# Altium schematic sheet Controller_Board_Interface.SchDoc
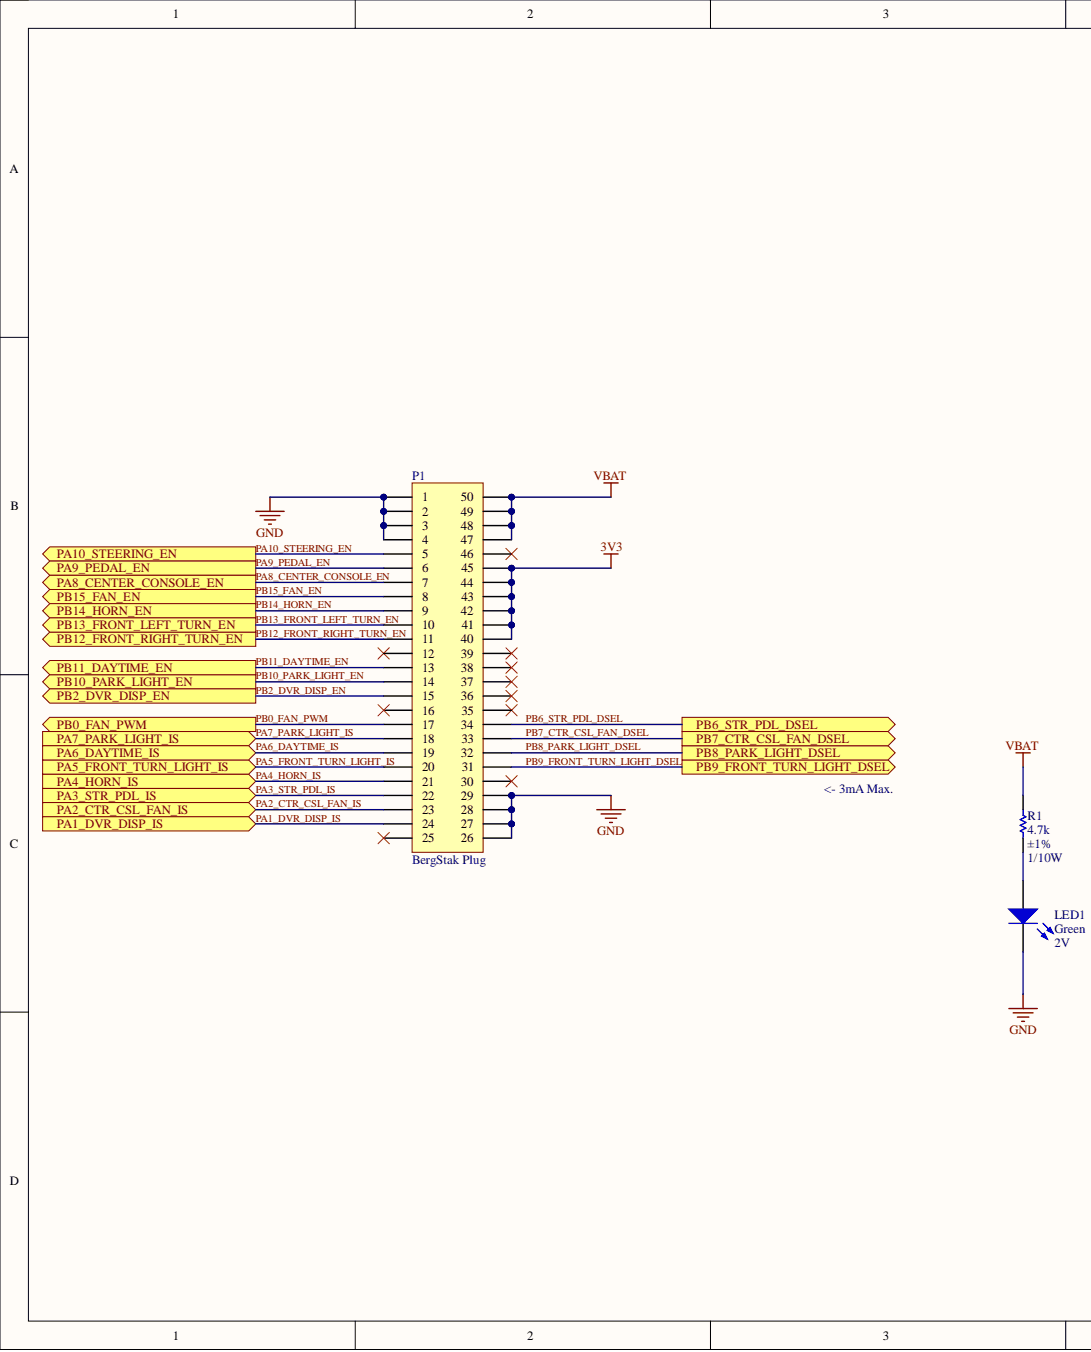
[diagram p0: Controller_Board_Interface.SchDoc, left]
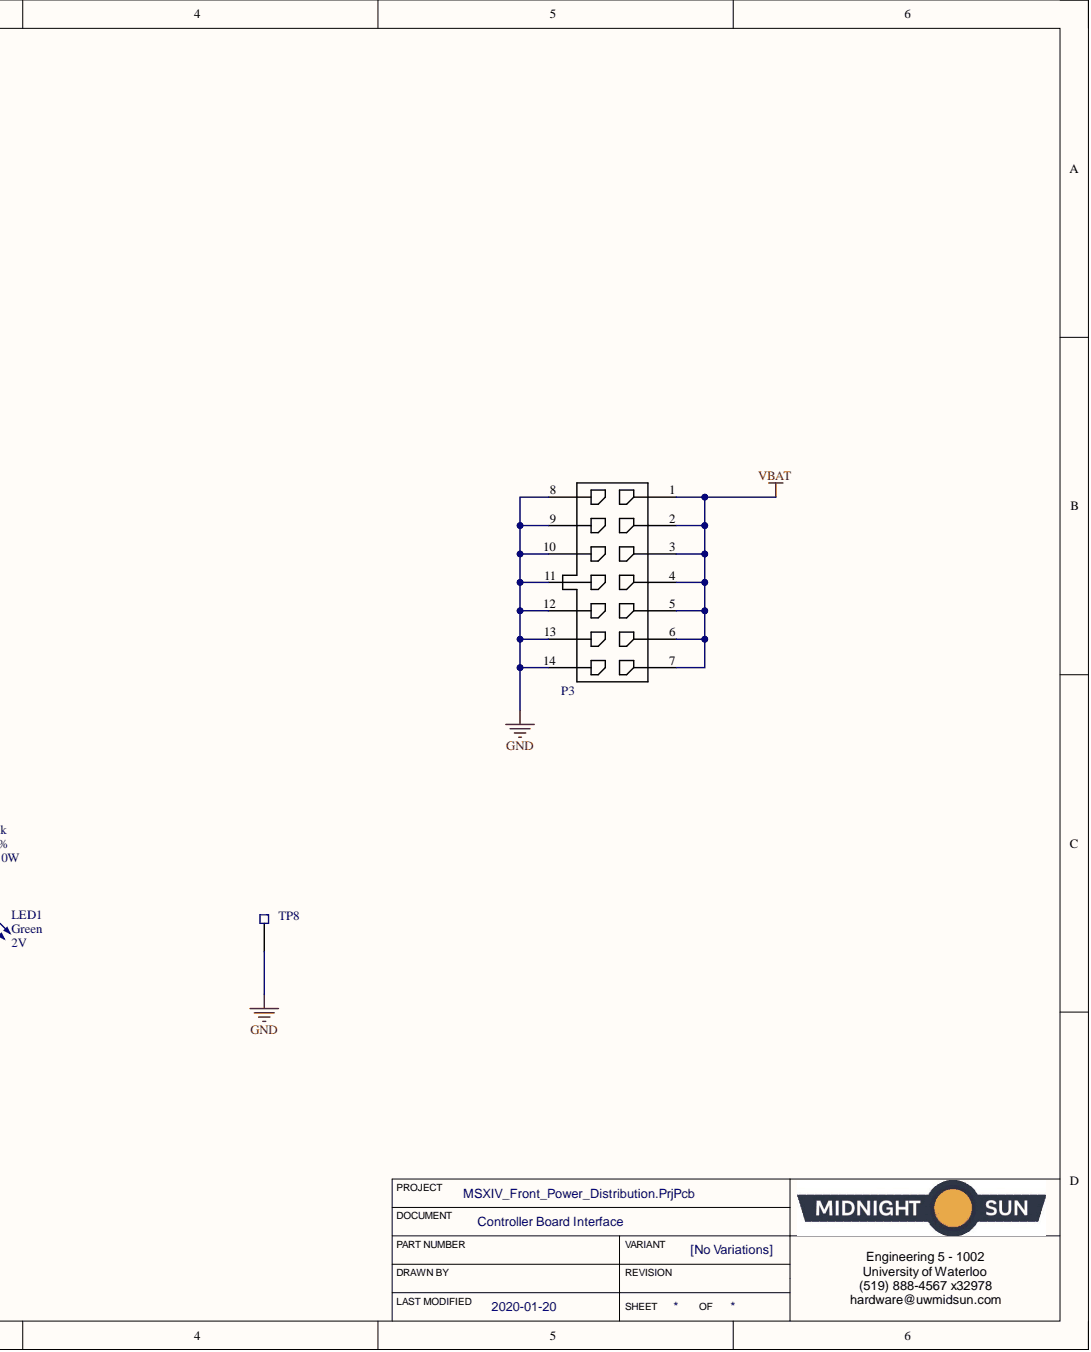
[diagram p1: Controller_Board_Interface.SchDoc, right]

## Parts
LED1  Color: Green  Voltage - Forward (Vf) (Typ): 2V  [LED_0603]  (hidden comment: LED GREEN CLEAR 2V 0603)
P1  Series: BergStak Plug  [CONN, Bergstak 50 Pos Plug]  (hidden comment: CONN 50POS Bergstak Plug 0.02")
P3  CONN 14POS MICRO-FIT 3mm  [CONN, 14POS MICRO-FIT 3mm]  (value not drawn)
R1  Power (Watts): 1/10W  Resistance (Ohms): 4.7k  Tolerance: ±1%  [RES, 0603]  (hidden comment: RES 4.7K OHM 1% 1/10W 0603)
TP8  Test Point 0603 SMD  [TEST POINT 0603 SMD]  (value not drawn)

## Ports
PA1_DVR_DISP_IS, PA2_CTR_CSL_FAN_IS, PA3_STR_PDL_IS, PA4_HORN_IS, PA5_FRONT_TURN_LIGHT_IS, PA6_DAYTIME_IS, PA7_PARK_LIGHT_IS, PA8_CENTER_CONSOLE_EN, PA9_PEDAL_EN, PA10_STEERING_EN, PB0_FAN_PWM, PB2_DVR_DISP_EN, PB6_STR_PDL_DSEL, PB7_CTR_CSL_FAN_DSEL, PB8_PARK_LIGHT_DSEL, PB9_FRONT_TURN_LIGHT_DSEL, PB10_PARK_LIGHT_EN, PB11_DAYTIME_EN, PB12_FRONT_RIGHT_TURN_EN, PB13_FRONT_LEFT_TURN_EN, PB14_HORN_EN, PB15_FAN_EN

## Nets
3V3: P1.40 P1.41 P1.42 P1.43 P1.44 P1.45
GND: LED1.2 P1.1 P1.2 P1.3 P1.4 P1.26 P1.27 P1.28 P1.29 P3.8 P3.9 P3.10 P3.11 P3.12 P3.13 P3.14 TP8.1
VBAT: P1.47 P1.48 P1.49 P1.50 P3.1 P3.2 P3.3 P3.4 P3.5 P3.6 P3.7 R1.1
PA1_DVR_DISP_IS: P1.24 ; port PA1_DVR_DISP_IS
PA2_CTR_CSL_FAN_IS: P1.23 ; port PA2_CTR_CSL_FAN_IS
PA3_STR_PDL_IS: P1.22 ; port PA3_STR_PDL_IS
PA4_HORN_IS: P1.21 ; port PA4_HORN_IS
PA5_FRONT_TURN_LIGHT_IS: P1.20 ; port PA5_FRONT_TURN_LIGHT_IS
PA6_DAYTIME_IS: P1.19 ; port PA6_DAYTIME_IS
PA7_PARK_LIGHT_IS: P1.18 ; port PA7_PARK_LIGHT_IS
PA8_CENTER_CONSOLE_EN: P1.7 ; port PA8_CENTER_CONSOLE_EN
PA9_PEDAL_EN: P1.6 ; port PA9_PEDAL_EN
PA10_STEERING_EN: P1.5 ; port PA10_STEERING_EN
PB0_FAN_PWM: P1.17 ; port PB0_FAN_PWM
PB2_DVR_DISP_EN: P1.15 ; port PB2_DVR_DISP_EN
PB6_STR_PDL_DSEL: P1.34 ; port PB6_STR_PDL_DSEL
PB7_CTR_CSL_FAN_DSEL: P1.33 ; port PB7_CTR_CSL_FAN_DSEL
PB8_PARK_LIGHT_DSEL: P1.32 ; port PB8_PARK_LIGHT_DSEL
PB9_FRONT_TURN_LIGHT_DSEL: P1.31 ; port PB9_FRONT_TURN_LIGHT_DSEL
PB10_PARK_LIGHT_EN: P1.14 ; port PB10_PARK_LIGHT_EN
PB11_DAYTIME_EN: P1.13 ; port PB11_DAYTIME_EN
PB12_FRONT_RIGHT_TURN_EN: P1.11 ; port PB12_FRONT_RIGHT_TURN_EN
PB13_FRONT_LEFT_TURN_EN: P1.10 ; port PB13_FRONT_LEFT_TURN_EN
PB14_HORN_EN: P1.9 ; port PB14_HORN_EN
PB15_FAN_EN: P1.8 ; port PB15_FAN_EN
NetLED1_1: LED1.1 R1.2

Not connected: P1 12 16 25 30 35 36 37 38 39 46

## Library data of the parts
LED1 = LED GREEN CLEAR 2V 0603: Green 572nm LED Indication - Discrete 2V 0603 (1608 Metric); Manufacturer: Wurth Electronics Inc.; Manufacturer Part Number: 150060VS75000; Current - Test: 20mA; Lens Color: Colorless; Lens Style/Size: Rectangle with Flat Top, 1.10mm x 0.70mm; Millicandela Rating: 40mcd; Viewing Angle: 140°; Wavelength - Dominant: 570nm; Category: Optoelectronics; Component Kind: Standard; ComponentLink1Description: http://katalog.we-online.de/led/datasheet/150060VS75000.pdf; ComponentLink1URL: http://katalog.we-online.de/led/datasheet/150060VS75000.pdf; Features: -; Height (Max): 0.80mm; Lens Transparency: Clear; Mounting Type: Surface Mount; Package / Case: 0603 (1608 Metric); Packaging: Cut Tape (CT); Part Status: Active; Pricing 1: 1=0.21, 100=0.1617, 500=0.13964 (CAD); RoHS: RoHS Compliant; Size / Dimension: 1.60mm L x 0.80mm W; Stock 1: 9408; Supplier 1: Digi-Key; Supplier Device Package: 0603; Supplier Part Number 1: 732-4980-1-ND; Wavelength - Peak: 572nm; Library Name: Schematic Diagrams.SchLib
P1 = CONN 50POS Bergstak Plug 0.02": 50 Position Connector Plug, Outer Shroud Contacts Surface Mount Gold; Manufacturer 1: Amphenol FCI; Manufacturer Part Number 1: 10132797-055100LF; Mated Stacking Heights: 5mm; Number of Positions: 50; Pitch: 0.020" (0.50mm); Component Kind: Standard; ComponentLink1Description: http://portal.fciconnect.com/Comergent//fci/drawing/10132797.pdf; ComponentLink1URL: http://portal.fciconnect.com/Comergent//fci/drawing/10132797.pdf; Connector Type: Plug, Outer Shroud Contacts; Contact Finish: Gold; Contact Finish Thickness: 1µin (0.025µm); Height Above Board: 0.169" (4.30mm); Mounting Type: Surface Mount; Number of Rows: 2; Packaging: Cut Tape (CT); Part Status: Active; Supplier 1: Digi-Key; Supplier Part Number 1: 609-5226-1-ND; Library Name: Schematic Diagrams.SchLib
P3 = CONN 14POS MICRO-FIT 3mm: Connector Header Through Hole 14 position 0.118" (3.00mm); Manufacturer: Molex, LLC; Manufacturer Part Number: 0430451427; Contact Length - Post: 0.125" (3.18mm); Material Flammability Rating: UL94 V-0; Number of Positions: 14; Number of Positions Loaded: All; Shrouding: Shrouded - 4 Wall; Style: Board to Cable/Wire; Applications: General Purpose, Medical, Military, Telecommunications; Category: Connectors, Interconnects; Component Kind: Standard; ComponentLink1Description: https://www.molex.com/pdm_docs/sd/430451427_sd.pdf; ComponentLink1URL: https://www.molex.com/pdm_docs/sd/430451427_sd.pdf; Connector Type: Header; Contact Finish - Mating: Tin; Contact Finish - Post: Tin; Contact Finish Thickness - Mating: 60.0µin (1.52µm); Contact Finish Thickness - Post: -; Contact Length - Mating: -; Contact Material: Brass; Contact Shape: Square; Contact Type: Male Pin; Current Rating: -; Fastening Type: Locking Ramp; Features: Board Guide; Ingress Protection: -; Insulation Color: Black; Insulation Height: 0.390" (9.91mm); Insulation Material: Liquid Crystal Polymer (LCP), Glass Filled; Mated Stacking Heights: -; Mounting Type: Through Hole; Number of Rows: 2; Operating Temperature: -; Overall Contact Length: -; Packaging: Tray; Part Status: Active; Pitch - Mating: 0.118" (3.00mm); Pricing 1: 1=4.57, 10=4.377, 25=4.0124, 50=3.8296, 100=3.6473, 250=3.1914, 936=2.82666, 1872=2.5531, 2808=2.46192 (CAD); RoHS: RoHS Compliant; Row Spacing - Mating: 0.118" (3.00mm); Stock 1: 829; Supplier 1: Digi-Key; Supplier Part Number 1: WM10707-ND; Termination: Solder; Voltage Rating: -; Library Name: Schematic Diagrams.SchLib
R1 = RES 4.7K OHM 1% 1/10W 0603: 4.7k Ohm ±1% 0.1W, 1/10W Chip Resistor 0603 (1608 Metric) Moisture Resistant Thick Film; Manufacturer 1: Yageo; Manufacturer Part Number 1: RC0603FR-074K7L; Description 1: RES SMD 4.7K OHM 1% 1/10W 0603; Temperature Coefficient: ±100ppm/°C; Category: Resistors; Component Kind: Standard; ComponentLink1Description: http://www.yageo.com/documents/recent/PYu-RC_Group_51_RoHS_L_7.pdf; ComponentLink1URL: http://www.yageo.com/documents/recent/PYu-RC_Group_51_RoHS_L_7.pdf; Composition: Thick Film; Failure Rate: -; Features: Moisture Resistant; Height - Seated (Max): 0.022" (0.55mm); Operating Temperature: -55°C ~ 155°C; Package / Case: 0603 (1608 Metric); Packaging: Cut Tape (CT); Part Status: Active; Pricing 1: 1=0.16, 10=0.022, 100=0.0089, 1000=0.00402, 2500=0.00349 (CAD); RoHS: RoHS Compliant; Size / Dimension: 0.063" L x 0.031" W (1.60mm x 0.80mm); Stock 1: 3487566; Supplier 1: Digi-Key; Supplier Device Package: 0603; Supplier Part Number 1: 311-4.70KHRCT-ND; Library Name: Schematic Diagrams.SchLib
TP8 = Test Point 0603 SMD: Manufacturer 1: TE Connectivity; Manufacturer Part Number 1: 1625854-3; Description 1: Thick Film Resistors - SMD 2000; Resistance: 0mR; Case Code (Imperial): 0603; Case Code (Metric): 1608; Case/Package: 0603; Colour: Natural; Component Kind: Standard; ComponentLink1Description: https://datasheet.ciiva.com/30100/1773266-a-30100967.pdf; ComponentLink1URL: https://datasheet.ciiva.com/30100/1773266-a-30100967.pdf; ComponentLink2Description: https://datasheet.ciiva.com/8914/eng-ds-1773266-a-8914634.pdf; ComponentLink2URL: https://datasheet.ciiva.com/8914/eng-ds-1773266-a-8914634.pdf; ComponentLink3Description: https://datasheet.ciiva.com/6439/eng-ds-1773266-a-6439163.pdf; ComponentLink3URL: https://datasheet.ciiva.com/6439/eng-ds-1773266-a-6439163.pdf; ComponentLink4Description: https://datasheet.ciiva.com/6439/eng-ds-1773266-a-6439164.pdf; ComponentLink4URL: https://datasheet.ciiva.com/6439/eng-ds-1773266-a-6439164.pdf; ComponentLink5Description: https://datasheet.ciiva.com/6439/eng-ds-1773266-a-6439165.pdf; ComponentLink5URL: https://datasheet.ciiva.com/6439/eng-ds-1773266-a-6439165.pdf; Depth: 0.8mm; Height: 1.15mm; Length: 1.6mm; Max Operating Temperature: 125°C; Military Standard: Not; Min Operating Temperature: -55°C; Mount: Surface Mount; Package Quantity: 2000; Packaging: Tape and Reel; Pricing 1: 1=0.22, 10=0.211, 25=0.18, 50=0.1574, 100=0.1462, 250=0.12932, 500=0.12368, 1000=0.1012 (USD); Radiation Hardening: No; Stock 1: 212628; Supplier 1: Digi-Key; Supplier Part Number 1: A106145CT-ND; Termination: SMD/SMT; Width: 0.8mm; Library Name: Schematic Diagrams.SchLib
